# Altium schematic sheet Fuel gauge.SchDoc("Fuel gauge")
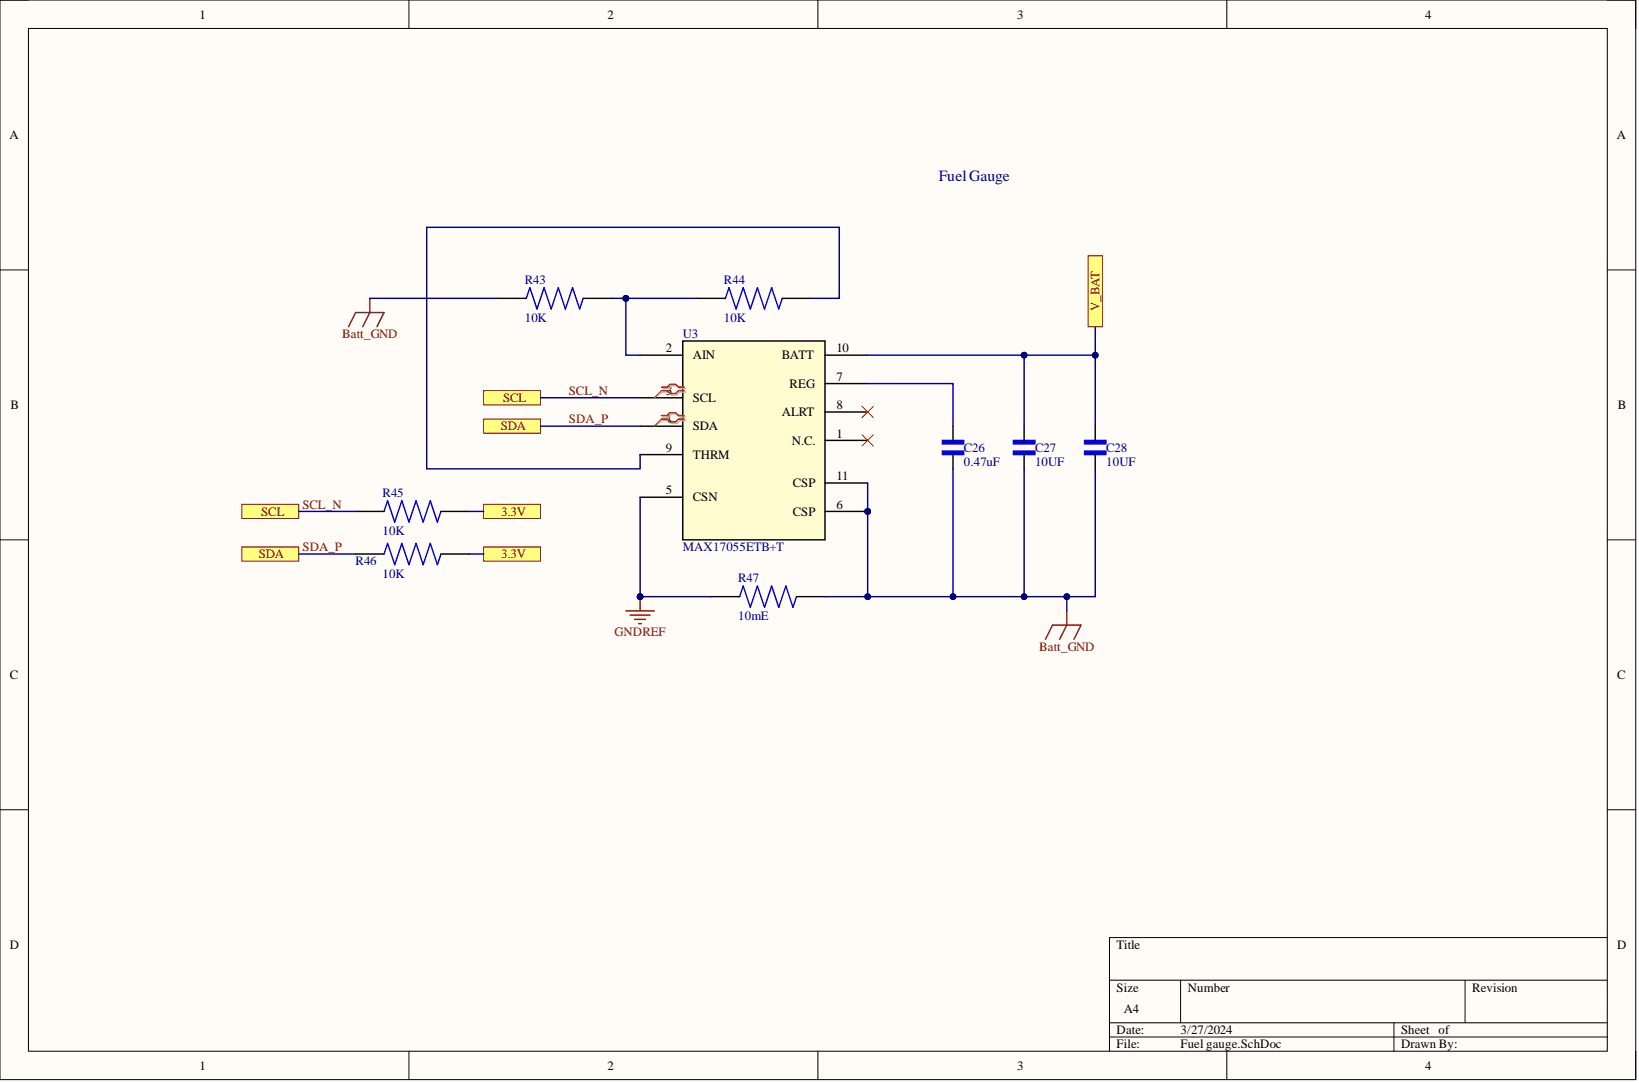
[diagram p0: Fuel gauge.SchDoc]

## Parts
C26  0.47uF
C27  10UF
C28  10UF
R43  10K
R44  10K
R45  10K
R46  10K
R47  10mE
U3  MAX17055ETB+T

## Ports
3.3V, SCL, SDA, V_BAT

## Nets
Batt_GND: C26.1 C27.1 C28.1 R43.1 R47.2 U3.6(CSP) U3.11(CSP)
GNDREF: R47.1 U3.5(CSN)
3.3V: R45.2 R46.2 ; port 3.3V
SCL_N: R45.1 U3.3(SCL) ; port SCL
SDA_P: R46.1 U3.4(SDA) ; port SDA
V_BAT: C27.2 C28.2 U3.10(BATT) ; port V_BAT
NetC26_2: C26.2 U3.7(REG)
NetR43_2: R43.2 R44.1 U3.2(AIN)
NetR44_2: R44.2 U3.9(THRM)

Not connected: U3 1(N.C.) 8(ALRT)

## Library data of the parts
C26 = TMK107B7474KA-TR: Cap Ceramic 0.47uF 25V X7R 10% SMD 0603 125Â°C Paper T/R; MF: {'manufacturer': u'Taiyo Yuden'}; Availability: Unavailable; Component Kind: Standard; MP: TMK107B7474KA-TR; Package: None; Price: None
C27 C28 = GRM188C81C106MA73J: Cap Ceramic 10uF 16V X6S 20% Pad SMD 0603 105Â°C T/R; MF: {'manufacturer': u'Murata'}; Availability: Unavailable; Component Kind: Standard; MP: GRM188C81C106MA73J; Package: None; Price: None
R43-R46 = RC0603FR-0710KL: YAGEO RC0603FR-0710KL / RESISTOR 10K 1/10W 1% 0603 YAG <a href="https://pricing.snapeda.com/parts/RC0603FR-0710KL/Yageo/view-part?ref=eda">Check availability</a>; MF: {'manufacturer': u'Yageo'}; Availability: Unavailable; Component Kind: Standard; MP: RC0603FR-0710KL; Package: None; Price: None
R47 = RLP73V3AR010JTE: Current Sense Resistors - SMD RLP73V 3A R010 5% 2K RL; MF: {'manufacturer': u'TE Connectivity'}; Availability: Unavailable; Component Kind: Standard; MP: RLP73V3AR010JTE; Package: None; Price: None
U3 = MAX17055ETB+T: No Description Available; Mfr_Name: Analog Devices Inc; Component Kind: Standard; Library Name: MAX17055ETB&plus_T.SchLib; Manufacturer_Part_Number: MAX17055ETB+T; RefDes: RefDes; Type: DEV; Copyright: Copyright (C) 2024 Ultra Librarian. All rights reserved.
